# Altium schematic sheet MLRS_E28_RX.SchDoc
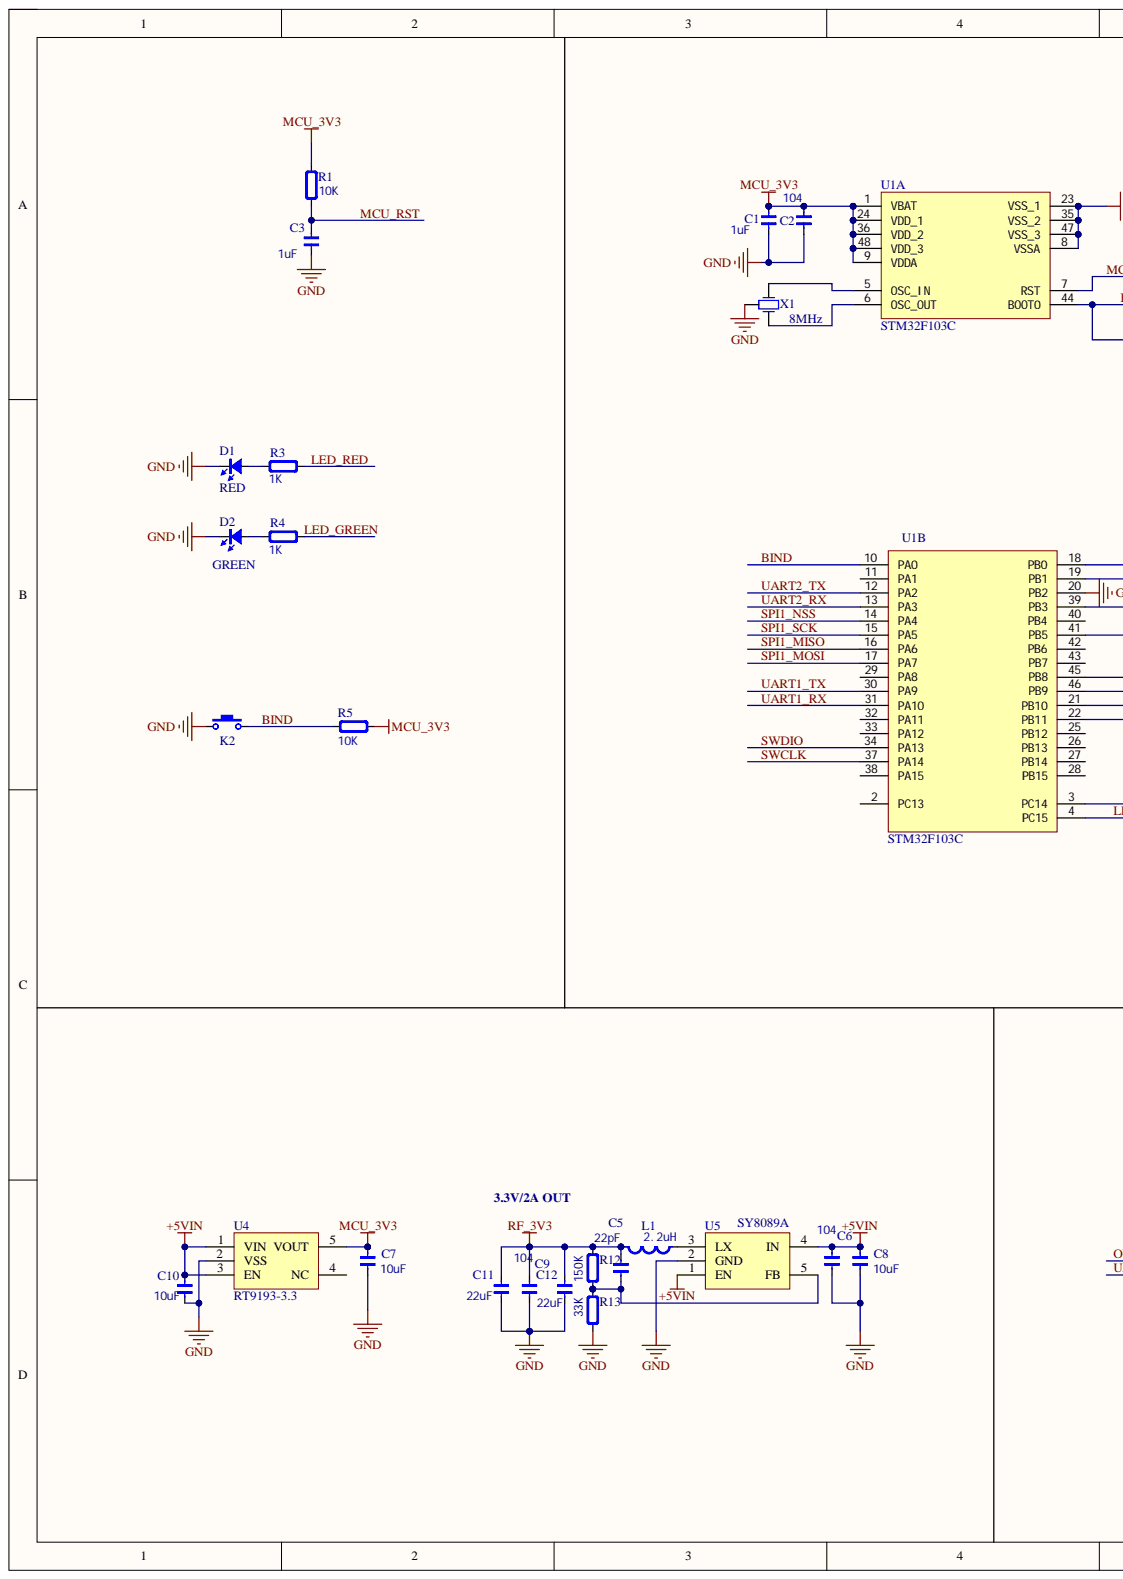
[diagram p0: MLRS_E28_RX.SchDoc, left]
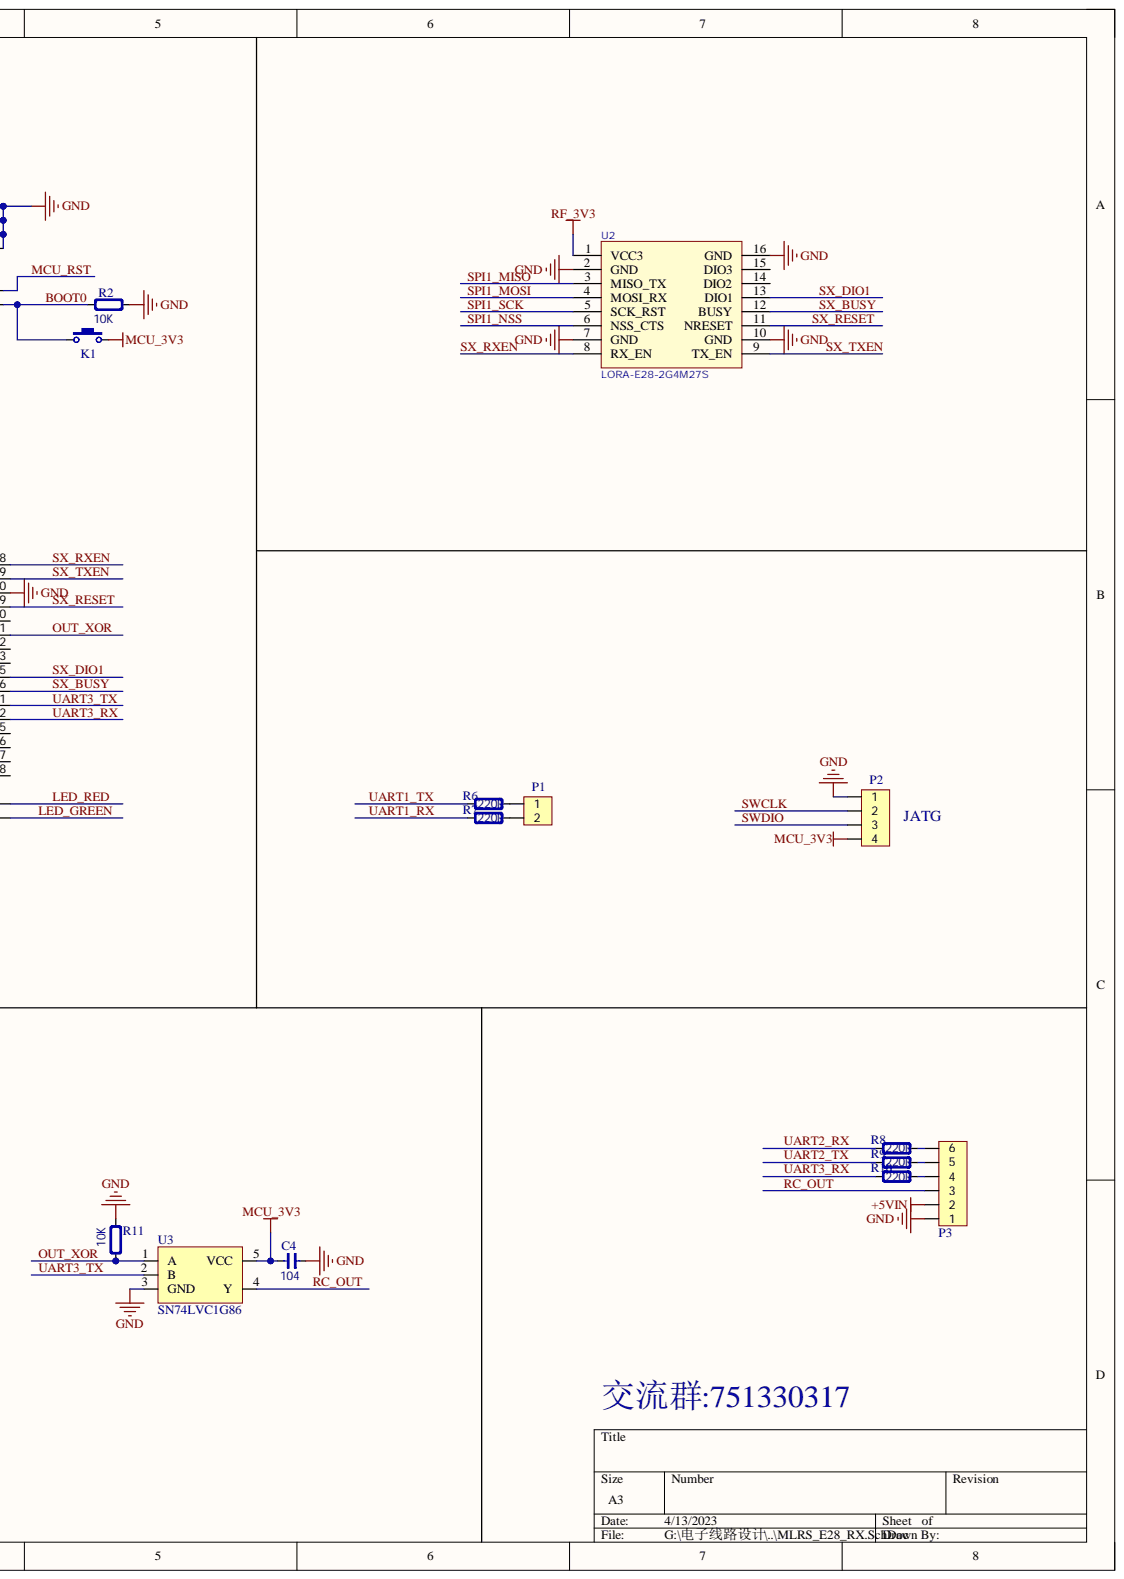
[diagram p1: MLRS_E28_RX.SchDoc, right]

## Parts
C1  1uF  [C0402S]
C2  104  [C0402S]
C3  1uF  [C0402S]
C4  104  [C0402S]
C5  22pF  [C0402S]
C6  104  [CAP_0603]
C7  10uF  [CAP_0603]
C8  10uF  [CAP_0805]
C9  104  [CAP_0603]
C10  10uF  [CAP_0603]
C11  22uF  [CAP_0805]
C12  22uF  [CAP_0805]
D1  RED  [LED_0603_RED]
D2  GREEN  [LED_0603_GREEN]
K1  TACT  [JUMP]  (value not drawn)
K2  TACT  [ButtonTACT_3x4x2_180]  (value not drawn)
L1  2.2uH  [NR4018]
P1  HDR1x2  [PAD_2.0_2P]  (value not drawn)
P2  HDR1x4  [JTAG_1.5_PAD]  (value not drawn)
P3  HDR1x6  [MX 1.25-WS-6P]  (value not drawn)
R1  10K  [R0402S]
R2  10K  [R0402S]
R3  1K  [R0402S]
R4  1K  [R0402S]
R5  10K  [R0402S]
R6  220R  [R0402S]
R7  220R  [R0402S]
R8  220R  [R0402S]
R9  220R  [R0402S]
R10  220R  [R0402S]
R11  10K  [R0402S]
R12  150K  [R0402S]
R13  33K  [R0402S]
U1  STM32F103C  [LQFP_P0.5_48]  (parts A,B)
U2  LORA-E28-2G4M27S  [E28-2G4M2XS]
U3  SN74LVC1G86  [SOT23-5]
U4  RT9193-3.3  [SOT23-5]
U5  SY8089A  [SOT23-5]
X1  8MHz  [OSC-3213]

## Nets
+5VIN: C6.2 C8.2 C10.2 P3.2 U4.1(VIN) U4.3(EN) U5.1(EN) U5.4(IN)
GND: C1.1 C2.1 C3.1 C4.2 C6.1 C7.1 C8.1 C9.1 C10.1 C11.1 C12.1 D1.2 D2.2 K2.1 P2.1 P3.1 R2.2 R11.1 R13.2 U1.8(VSSA) U1.20(PB2) U1.23(VSS_1) U1.35(VSS_2) U1.47(VSS_3) U2.2(GND) U2.7(GND) U2.10(GND) U2.16(GND) U3.3(GND) U4.2(VSS) U5.2(GND) X1.2
MCU_3V3: C1.2 C2.2 C4.1 C7.2 K1.2 P2.4 R1.2 R5.1 U1.1(VBAT) U1.9(VDDA) U1.24(VDD_1) U1.36(VDD_2) U1.48(VDD_3) U3.5(VCC) U4.5(VOUT)
RF_3V3: C5.2 C9.2 C11.2 C12.2 L1.1 R12.1 U2.1(VCC3)
BIND: K2.2 R5.2 U1.10(PA0)
BOOT0: K1.1 R2.1 U1.44(BOOT0)
LED_GREEN: R4.1 U1.4(PC15)
LED_RED: R3.1 U1.3(PC14)
MCU_RST: C3.2 R1.1 U1.7(RST)
OUT_XOR: R11.2 U1.41(PB5) U3.1(A)
RC_OUT: P3.3 U3.4(Y)
SPI1_MISO: U1.16(PA6) U2.3(MISO_TX)
SPI1_MOSI: U1.17(PA7) U2.4(MOSI_RX)
SPI1_NSS: U1.14(PA4) U2.6(NSS_CTS)
SPI1_SCK: U1.15(PA5) U2.5(SCK_RST)
SWCLK: P2.2 U1.37(PA14)
SWDIO: P2.3 U1.34(PA13)
SX_BUSY: U1.46(PB9) U2.12(BUSY)
SX_DIO1: U1.45(PB8) U2.13(DIO1)
SX_RESET: U1.39(PB3) U2.11(NRESET)
SX_RXEN: U1.18(PB0) U2.8(RX_EN)
SX_TXEN: U1.19(PB1) U2.9(TX_EN)
UART1_RX: R7.1 U1.31(PA10)
UART1_TX: R6.1 U1.30(PA9)
UART2_RX: R8.1 U1.13(PA3)
UART2_TX: R9.1 U1.12(PA2)
UART3_RX: R10.1 U1.22(PB11)
UART3_TX: U1.21(PB10) U3.2(B)
NetC5_1: C5.1 R12.2 R13.1 U5.5(FB)
NetD1_1: D1.1 R3.2
NetD2_1: D2.1 R4.2
NetL1_2: L1.2 U5.3(LX)
NetP1_1: P1.1 R6.2
NetP1_2: P1.2 R7.2
NetP3_4: P3.4 R10.2
NetP3_5: P3.5 R9.2
NetP3_6: P3.6 R8.2
NetU1_5: U1.5(OSC_IN) X1.3
NetU1_6: U1.6(OSC_OUT) X1.1

Not connected: U1 2(PC13) 11(PA1) 25(PB12) 26(PB13) 27(PB14) 28(PB15) 29(PA8) 32(PA11) 33(PA12) 38(PA15) 40(PB4) 42(PB6) 43(PB7); U2 14(DIO2) 15(DIO3); U4 4(NC)

## Library data of the parts
C1-C12 = Cap_0603: Capacitor; Note: ±10%; Specification: X7R, ±10%, 16V; Type: 0603; Value: ?F; Component Kind: Standard; Library Name: SCH_Passive.SchLib
D1 = LED_0603: LED; Type: 0603; Component Kind: Standard; Library Name: SCH_Active.SchLib; Value: RED
D2 = LED_0603: LED; Type: 0603; Value: RED; Component Kind: Standard; Library Name: SCH_Active.SchLib
K1 K2 = ButtonTACT_180_3x4x2: Button; value: 3x4mm; Component Kind: Standard; Library Name: SCH_Switch.SchLib; type: TACT 2P
L1 = Ind_0603: Inductor; Note: ±5%; Specification: High frequency chip inductor ±5%; Type: 0603; Value: 10uH; Component Kind: Standard; Library Name: SCH_Passive.SchLib
P1 = HDR1x2_2.54: Header; Value: 2.54; Component Kind: Standard; Library Name: SCH_ConnectorHDR.SchLib; Type: DIP 1x2
P2 = HDR1x4_2.54: Header; Value: 2.54; Component Kind: Standard; Library Name: SCH_ConnectorHDR.SchLib; Type: DIP 1x4
P3 = HDR1x6_2.54: Header; Value: 2.54; Component Kind: Standard; Library Name: SCH_ConnectorHDR.SchLib; Type: DIP 1x6
R1 R2 R5-R13 = Res_0402: Resistor; Note: ±1%; Value: 1K; Component Kind: Standard; Library Name: SCH_Passive.SchLib; Specification: ±1%; Type: 0402
R3 R4 = Res_0402: Resistor; Note: ±1%; Component Kind: Standard; Library Name: SCH_Passive.SchLib; Specification: ±1%; Type: 0402; Value: 1K
U1 = STM32F103C: MCU ST; Manufacturer: STMicroelectronics; Note: CortexM3; Component Kind: Standard; Library Name: SCH_Microcontroller.SchLib; Type: LQFP48 P0.5
U4 = SPX3819M5: Component Kind: Standard; Library Name: SCH_Power.SchLib
X1 = XTAL_3213_3P: Crystal Oscillator; Specification: ±10ppm; Value: ?MHz; Component Kind: Standard; Library Name: SCH_Oscillator.SchLib; Type: XTAL5032_2P
U2 = LORA-E28-2G4M27S: Component Kind: Standard; Library Name: Schlib.SchLib
U3 = SN74LVC1G86: Component Kind: Standard; Library Name: Schlib.SchLib
U5 = SY8089A: Component Kind: Standard; Library Name: Schlib.SchLib
